# Altium schematic sheet Processor.SchDoc(Processor)
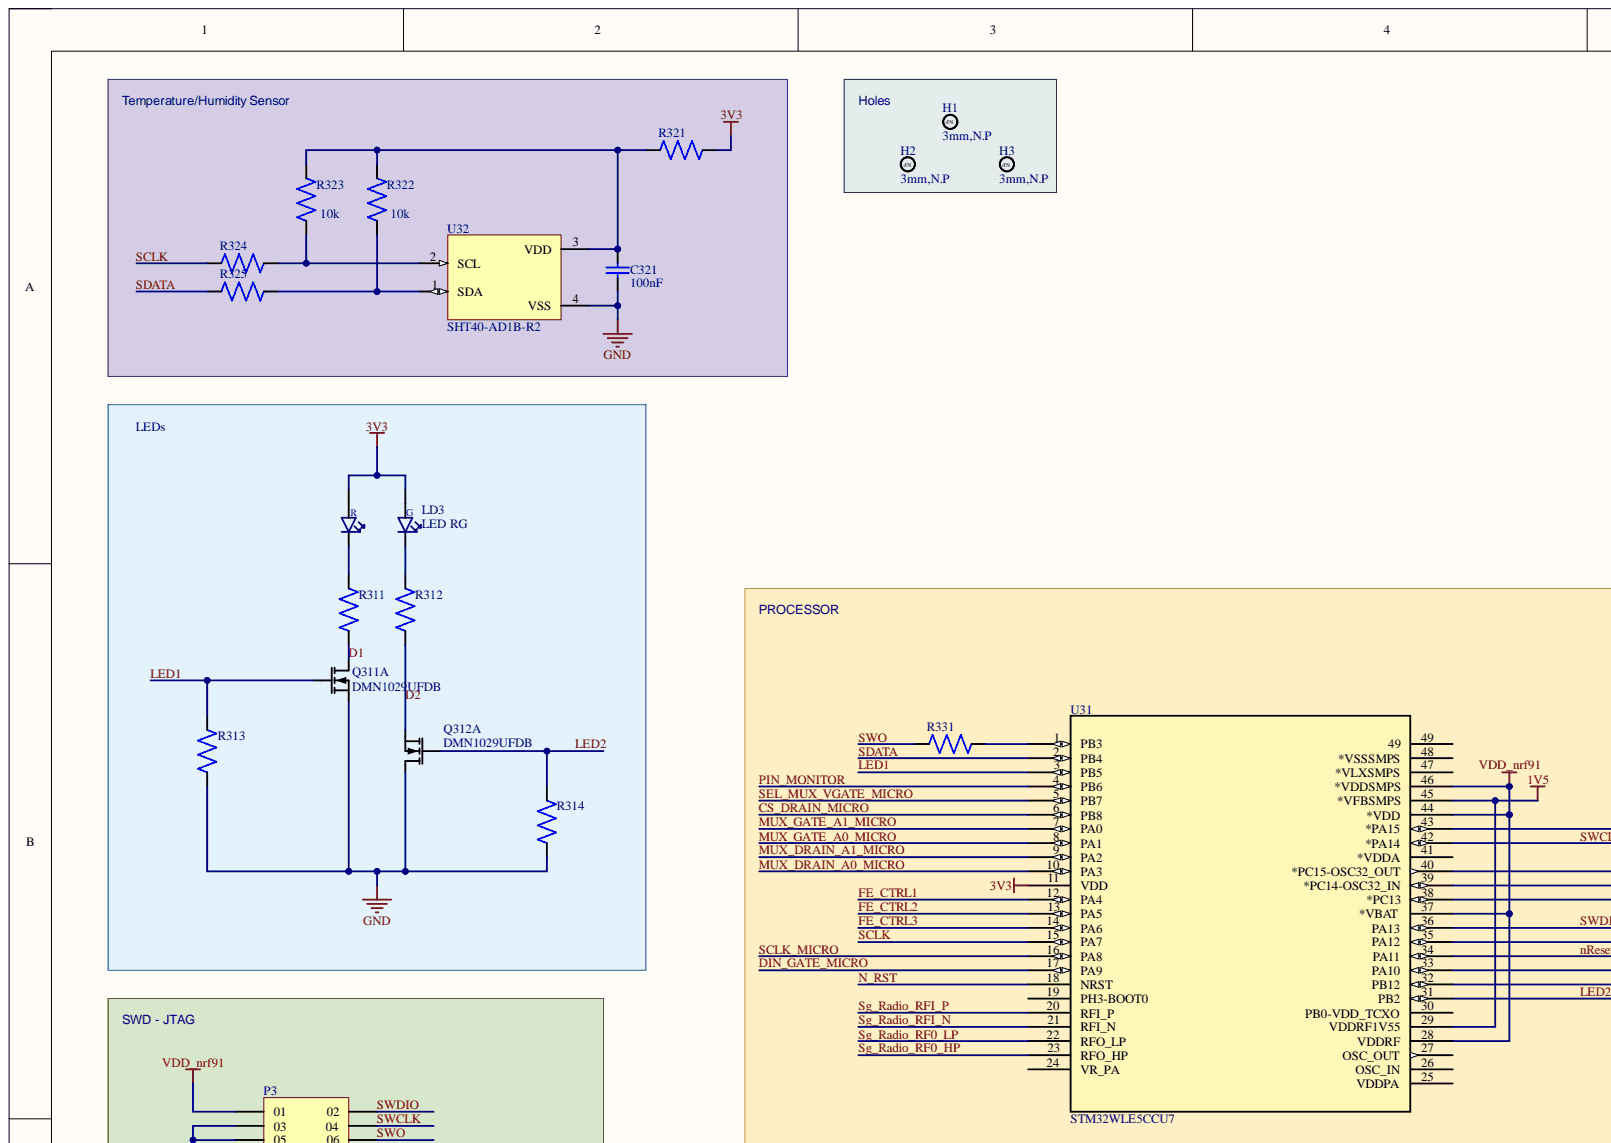
[diagram p0: Processor.SchDoc, top left]
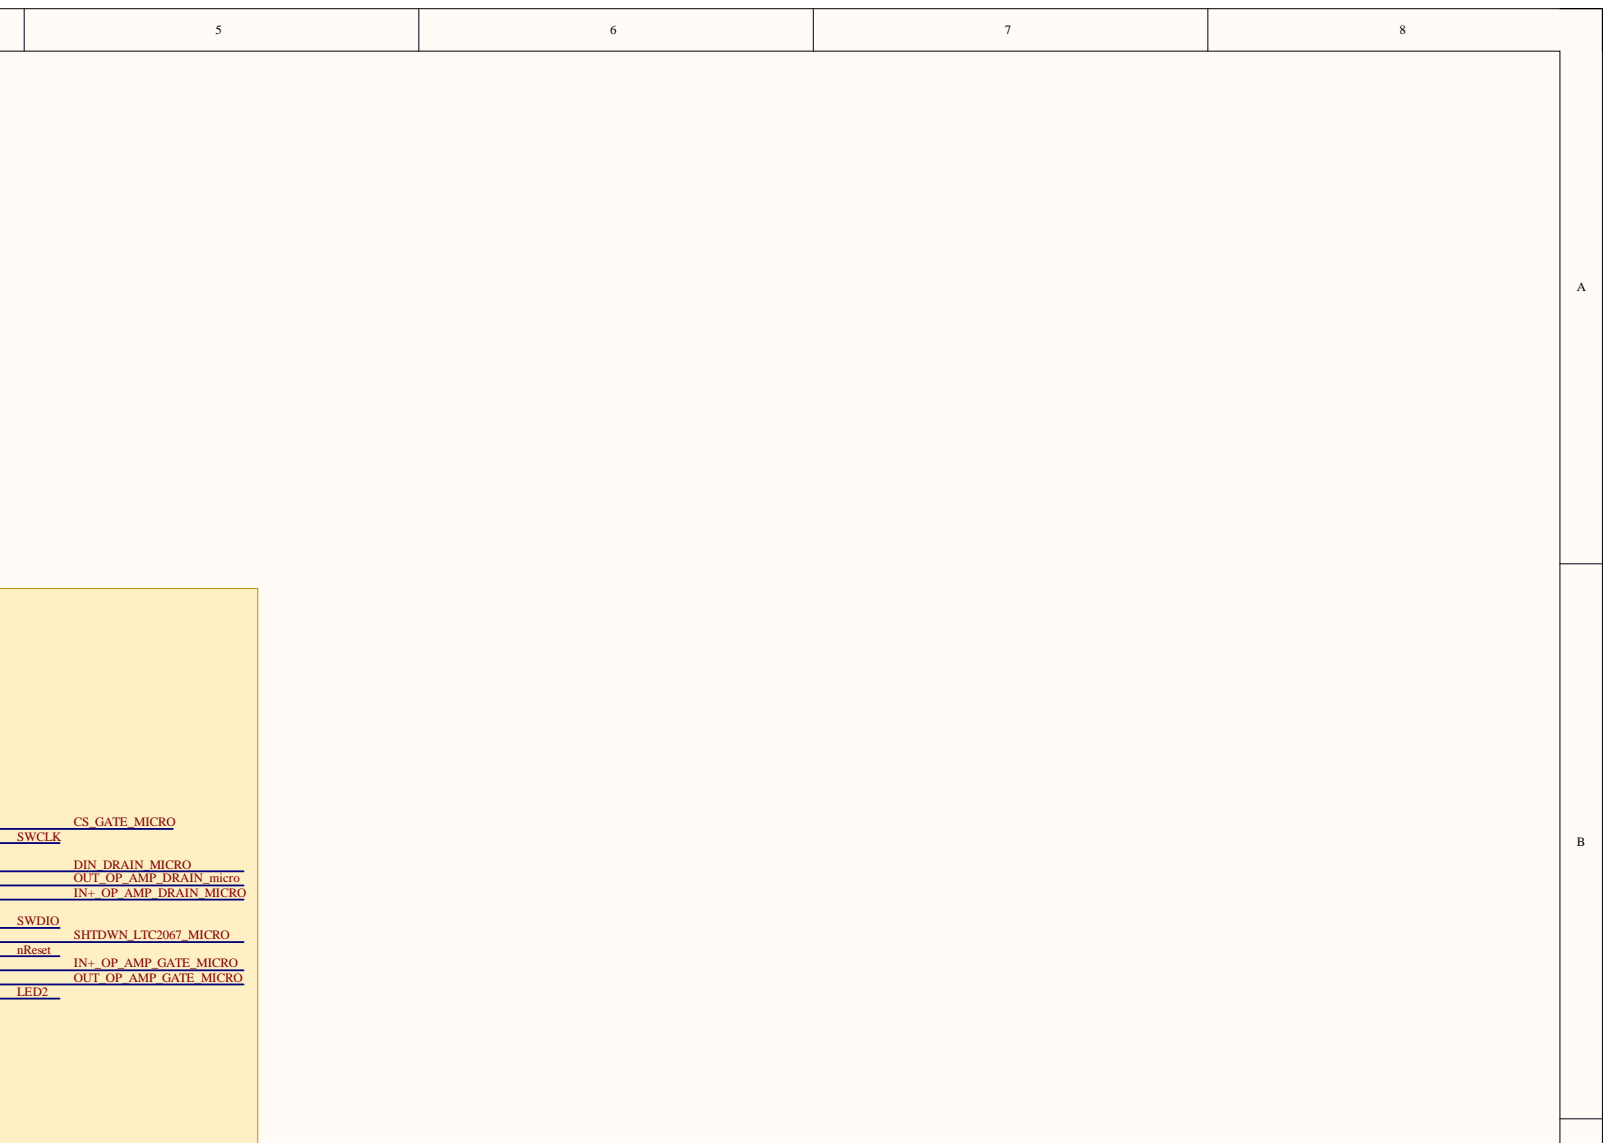
[diagram p1: Processor.SchDoc, top right]
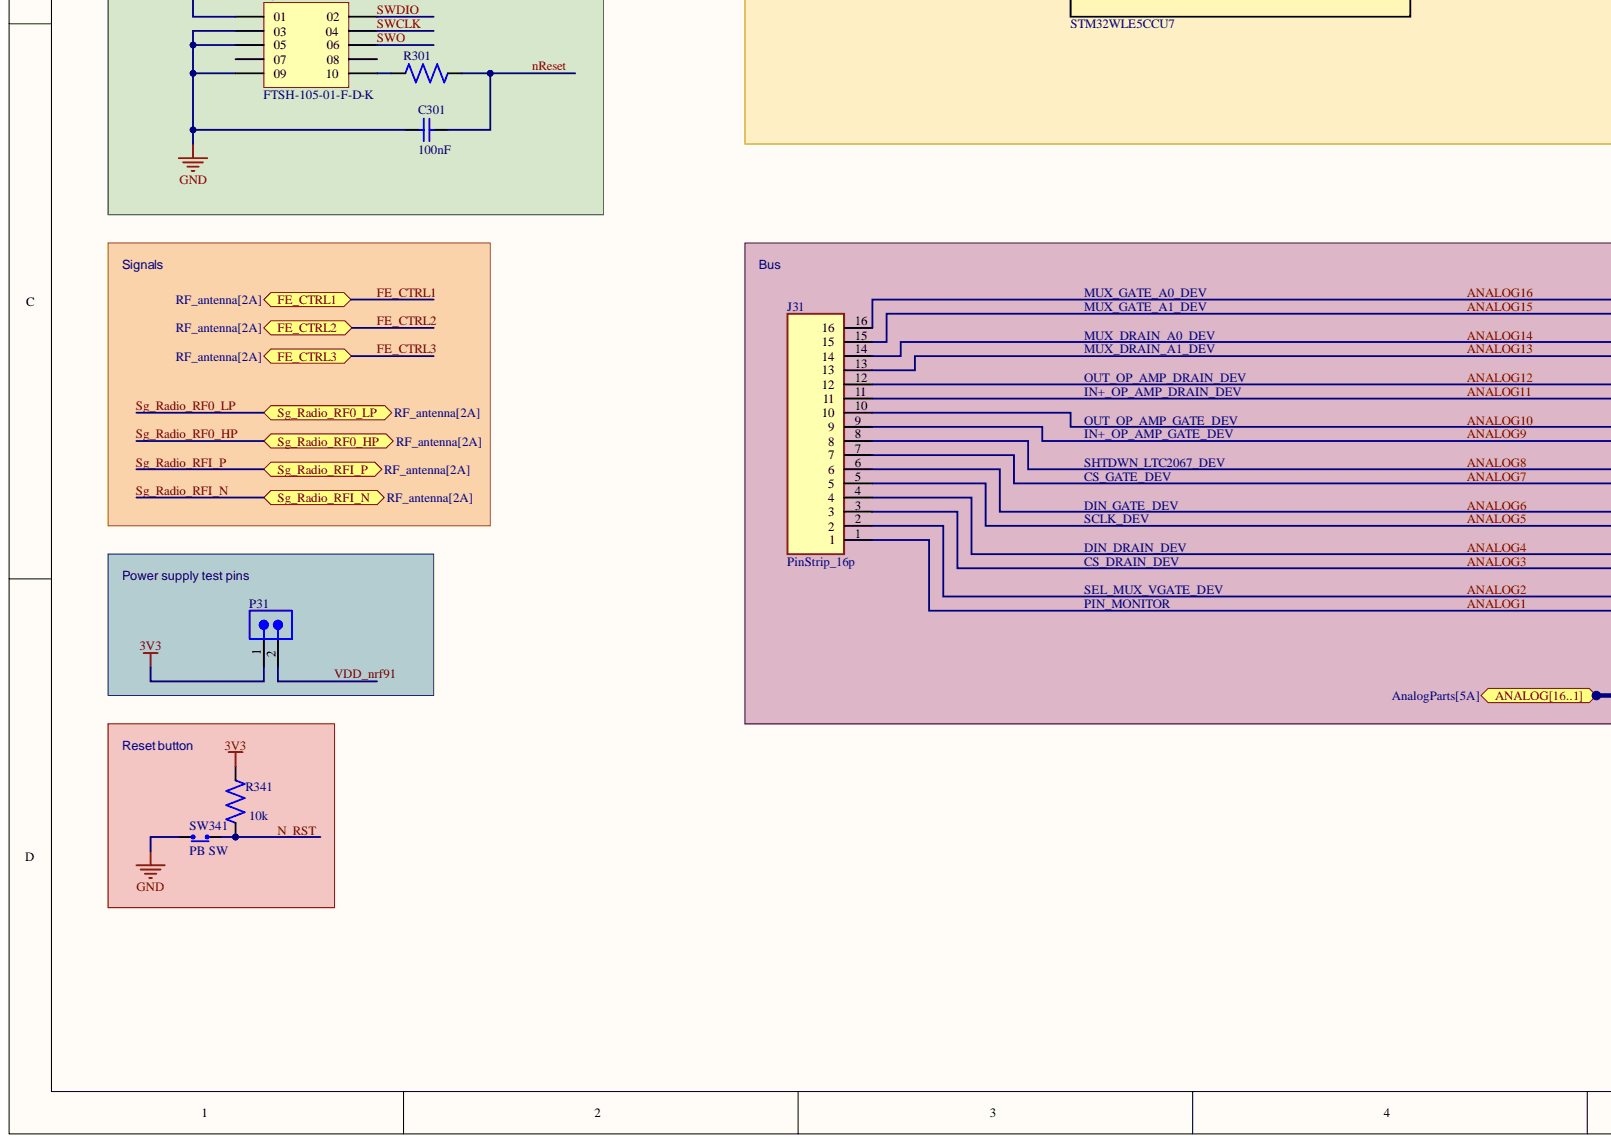
[diagram p2: Processor.SchDoc, bottom left]
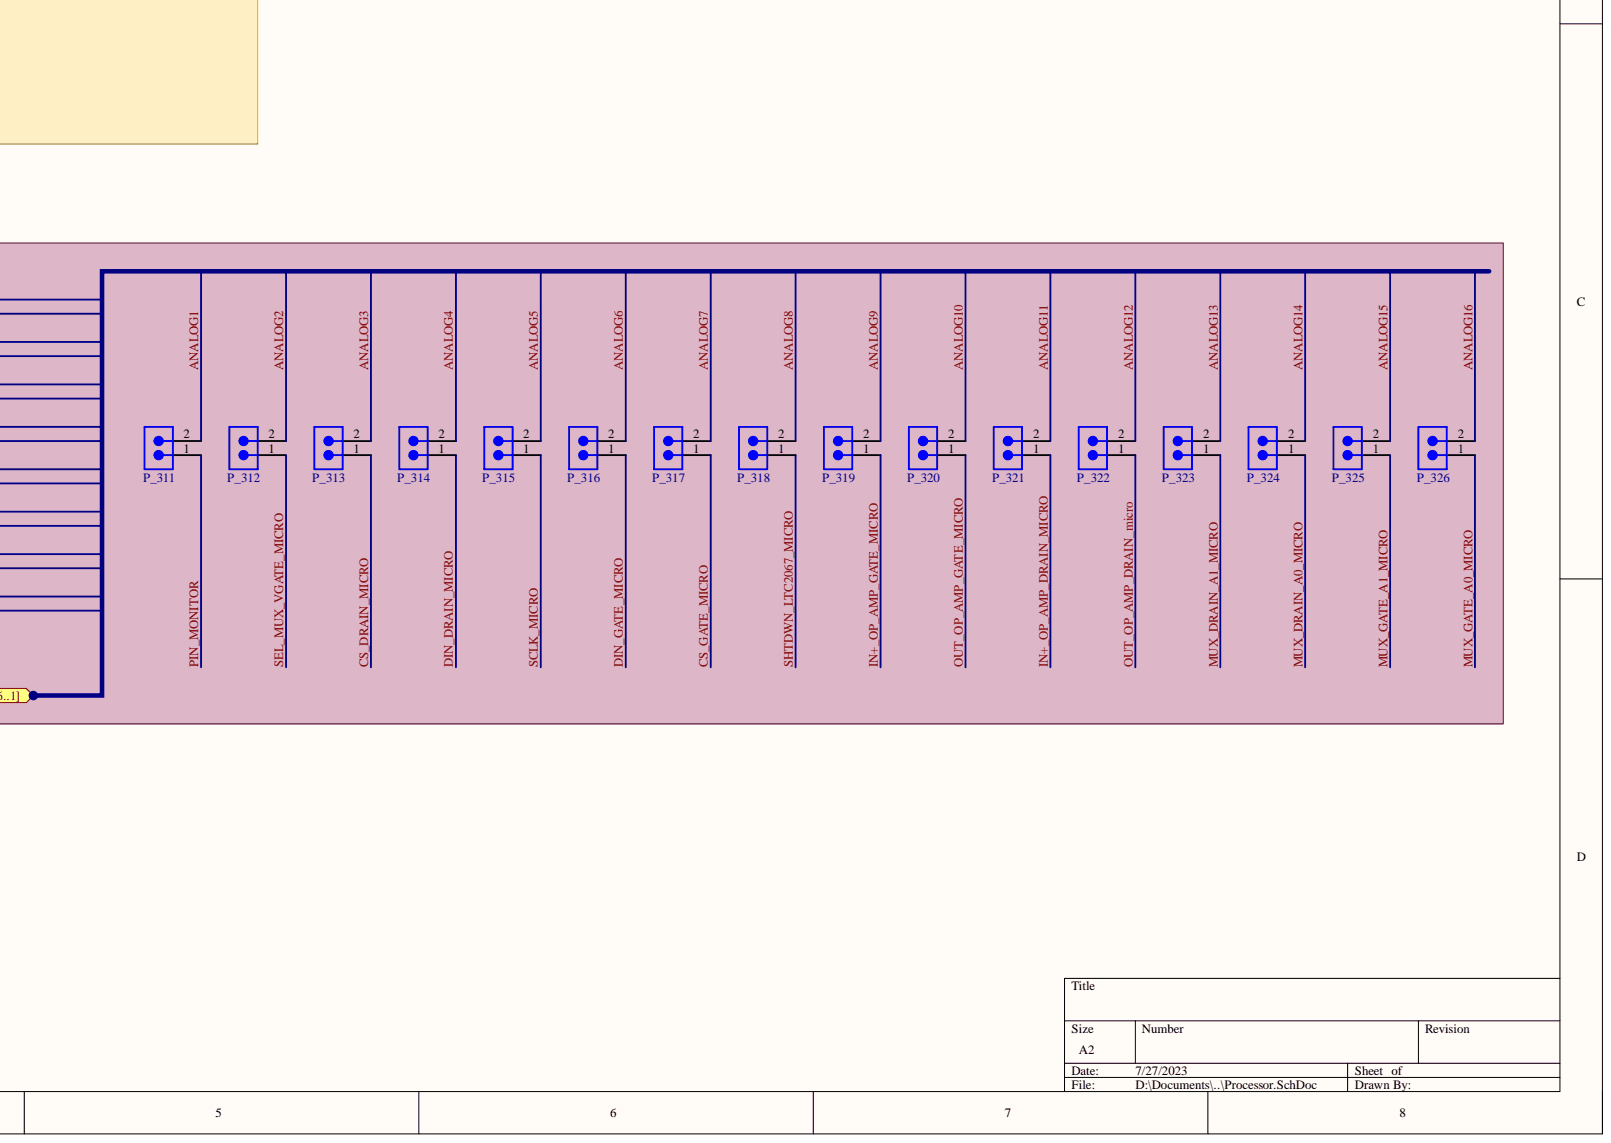
[diagram p3: Processor.SchDoc, bottom right]

## Parts
C301  100nF  [CAPC1608X06L]
C321  100nF  [CAPC1608X06L]
H1  3mm,N.P  [HOLE_NP_3MM]  (no BOM)
H2  3mm,N.P  [HOLE_NP_3MM]  (no BOM)
H3  3mm,N.P  [HOLE_NP_3MM]  (no BOM)
J31  PinStrip_16p  [PinStrip_16p]
LD3  LED RG  [LED_RGB_0805]
P3  FTSH-105-01-F-D-K  [HDR_2x5-THT-1.27mm-K]
P31  Pin header 2p  [Pin_header_1x2]  (value not drawn)
P_311  Pin header 2p  [Pin_header_1x2]  (value not drawn)
P_312  Pin header 2p  [Pin_header_1x2]  (value not drawn)
P_313  Pin header 2p  [Pin_header_1x2]  (value not drawn)
P_314  Pin header 2p  [Pin_header_1x2]  (value not drawn)
P_315  Pin header 2p  [Pin_header_1x2]  (value not drawn)
P_316  Pin header 2p  [Pin_header_1x2]  (value not drawn)
P_317  Pin header 2p  [Pin_header_1x2]  (value not drawn)
P_318  Pin header 2p  [Pin_header_1x2]  (value not drawn)
P_319  Pin header 2p  [Pin_header_1x2]  (value not drawn)
P_320  Pin header 2p  [Pin_header_1x2]  (value not drawn)
P_321  Pin header 2p  [Pin_header_1x2]  (value not drawn)
P_322  Pin header 2p  [Pin_header_1x2]  (value not drawn)
P_323  Pin header 2p  [Pin_header_1x2]  (value not drawn)
P_324  Pin header 2p  [Pin_header_1x2]  (value not drawn)
P_325  Pin header 2p  [Pin_header_1x2]  (value not drawn)
P_326  Pin header 2p  [Pin_header_1x2]  (value not drawn)
Q311  DMN1029UFDB  [MOSFET_DMP2160UFDB-7]  (part A)
Q312  DMN1029UFDB  [MOSFET_DMP2160UFDB-7]  (part A)
R301  (no value)  [RESC1608X04.5L]
R311  (no value)  [RESC1608X04.5L]
R312  (no value)  [RESC1608X04.5L]
R313  (no value)  [RESC1608X04.5L]
R314  (no value)  [RESC1608X04.5L]
R321  (no value)  [RESC1608X04.5L]
R322  10k  [RESC1608X04.5L]
R323  10k  [RESC1608X04.5L]
R324  (no value)  [RESC1608X04.5L]
R325  (no value)  [RESC1608X04.5L]
R331  (no value)  [RESC1608X04.5L]
R341  10k  [RESC1608X04.5L]
SW341  PB SW  [Switch-PB-6.2x6.3mm-SMD]
U31  STM32WLE5CCU7  [UFQFPN_5CCU7_STM]
U32  SHT40-AD1B-R2  [SHT4X]

## Ports
ANALOG1, ANALOG2, ANALOG3, ANALOG4, ANALOG5, ANALOG6, ANALOG7, ANALOG8, ANALOG9, ANALOG10, ANALOG11, ANALOG12, ANALOG13, ANALOG14, ANALOG15, ANALOG16, ANALOG[16..1], FE_CTRL1, FE_CTRL2, FE_CTRL3, Sg_Radio_RF0_HP, Sg_Radio_RF0_LP, Sg_Radio_RFI_N, Sg_Radio_RFI_P

## Nets
1V5: U31.29(VDDRF1V55) U31.45(*VFBSMPS)
3V3: LD3.3 LD3.4 P31.1 R321.2 R341.2 U31.11(VDD)
GND: C301.2 C321.1 P3.03 P3.05 P3.09 Q311.1 Q312.6 R313.1 R314.1 SW341.1 U32.4(VSS)
ANALOG1: J31.1 P_311.2 ; port ANALOG[16..1]  [also labelled ]
ANALOG2: J31.2 P_312.2 ; port ANALOG[16..1]  [also labelled ]
ANALOG3: J31.3 P_313.2 ; port ANALOG[16..1]  [also labelled ]
ANALOG4: J31.4 P_314.2 ; port ANALOG[16..1]  [also labelled ]
ANALOG5: J31.5 P_315.2 ; port ANALOG[16..1]  [also labelled ]
ANALOG6: J31.6 P_316.2 ; port ANALOG[16..1]  [also labelled ]
ANALOG7: J31.7 P_317.2 ; port ANALOG[16..1]  [also labelled ]
ANALOG8: J31.8 P_318.2 ; port ANALOG[16..1]  [also labelled ]
ANALOG9: J31.9 P_319.2 ; port ANALOG[16..1]  [also labelled ]
ANALOG10: J31.10 P_320.2 ; port ANALOG[16..1]  [also labelled ]
ANALOG11: J31.11 P_321.2 ; port ANALOG[16..1]  [also labelled ]
ANALOG12: J31.12 P_322.2 ; port ANALOG[16..1]  [also labelled ]
ANALOG13: J31.13 P_323.2 ; port ANALOG[16..1]  [also labelled ]
ANALOG14: J31.14 P_324.2 ; port ANALOG[16..1]  [also labelled ]
ANALOG15: J31.15 P_325.2 ; port ANALOG[16..1]  [also labelled ]
ANALOG16: J31.16 P_326.2 ; port ANALOG[16..1]  [also labelled ]
CS_DRAIN_MICRO: P_313.1 U31.6(PB8)
CS_GATE_MICRO: P_317.1 U31.43(*PA15)
D1: Q311.6 R311.1
D2: Q312.1 R312.1
DIN_DRAIN_MICRO: P_314.1 U31.40(*PC15-OSC32_OUT)
DIN_GATE_MICRO: P_316.1 U31.17(PA9)
FE_CTRL1: U31.12(PA4) ; port FE_CTRL1
FE_CTRL2: U31.13(PA5) ; port FE_CTRL2
FE_CTRL3: U31.14(PA6) ; port FE_CTRL3
IN+_OP_AMP_DRAIN_MICRO: P_321.1 U31.38(*PC13)
IN+_OP_AMP_GATE_MICRO: P_319.1 U31.33(PA10)
LED1: Q311.2 R313.2 U31.3(PB5)
LED2: Q312.2 R314.2 U31.31(PB2)
MUX_DRAIN_A0_MICRO: P_324.1 U31.10(PA3)
MUX_DRAIN_A1_MICRO: P_323.1 U31.9(PA2)
MUX_GATE_A0_MICRO: P_326.1 U31.8(PA1)
MUX_GATE_A1_MICRO: P_325.1 U31.7(PA0)
N_RST: R341.1 SW341.2 U31.18(NRST)
nReset: C301.1 R301.2 U31.34(PA11)
OUT_OP_AMP_DRAIN_micro: P_322.1 U31.39(*PC14-OSC32_IN)
OUT_OP_AMP_GATE_MICRO: P_320.1 U31.32(PB12)
PIN_MONITOR: P_311.1 U31.4(PB6)
SCLK: R324.1 U31.15(PA7)
SCLK_MICRO: P_315.1 U31.16(PA8)
SDATA: R325.1 U31.2(PB4)
SEL_MUX_VGATE_MICRO: P_312.1 U31.5(PB7)
Sg_Radio_RF0_HP: U31.23(RFO_HP) ; port Sg_Radio_RF0_HP
Sg_Radio_RF0_LP: U31.22(RFO_LP) ; port Sg_Radio_RF0_LP
Sg_Radio_RFI_N: U31.21(RFI_N) ; port Sg_Radio_RFI_N
Sg_Radio_RFI_P: U31.20(RFI_P) ; port Sg_Radio_RFI_P
SHTDWN_LTC2067_MICRO: P_318.1 U31.35(PA12)
SWCLK: P3.04 U31.42(*PA14)
SWDIO: P3.02 U31.36(PA13)
SWO: P3.06 R331.1
VDD_nrf91: P3.01 P31.2 U31.28(VDDRF) U31.37(*VBAT) U31.44(*VDD) U31.46(*VDDSMPS)
NetC321_2: C321.2 R321.1 R322.2 R323.2 U32.3(VDD)
NetLD3_1: LD3.1 R312.2
NetLD3_2: LD3.2 R311.2
NetP3_10: P3.10 R301.1
NetR322_1: R322.1 R325.2 U32.1(SDA)
NetR323_1: R323.1 R324.2 U32.2(SCL)
NetR331_2: R331.2 U31.1(PB3)

Not connected: P3 07 08; U31 19(PH3-BOOT0) 24(VR_PA) 25(VDDPA) 26(OSC_IN) 27(OSC_OUT) 30(PB0-VDD_TCXO) 41(*VDDA) 47(*VLXSMPS) 48(*VSSSMPS) 49

## Library data of the parts
C301 C321 = C0603-4µ7: Capacitor, X5R, ±10%; Component Kind: Standard; Value: 100nF; Library Name: ProgettoAgrifoodLibreriaSCH.SchLib
H1-H3 = Hole_3MM_NP: Regular Non-Plated, 3mm hole; Value: 3mm,N.P; Library Name: ProgettoAgrifoodLibreriaSCH.SchLib
J31 = PinStrip_16p: Connector; Manufacturer_Name: Adam Tech; Manufacturer_Part_Number: PH1-16-UA; Arrow Part Number: PH1-16-UA; Arrow Price/Stock: https://www.arrow.com/en/products/ph1-16-ua/adam-tech?region=nac; Component Kind: Standard; Datasheet Link: https://www.arrow.com/en/products/ph1-16-ua/adam-tech; Height: 8.469mm; Library Name: add2.SchLib
LD3 = APHBM2012LSURKZGKC: Red green LED 2 mm x 1.25 mm SMD; Component Kind: Standard; Value: LED RG; Library Name: ProgettoAgrifoodLibreriaSCH.SchLib
P3 = Pin Header 2x5 THT, 1.27mm KS: Connector Header Through Hole 10 position 0.050 (1.27mm); MF: Samtec; Availability: Bad; Component Kind: Standard; MP: FTSH-105-01-F-D-K; Package: None; Price: None; SnapEDA_Link: https://www.snapeda.com/parts/FTSH-105-01-F-D-K/Samtec%20Inc./view-part/229423/?ref=snap; Toby_UK-Purchase-URL: https://snapeda.com/shop?store=Toby+UK&id=229423; Value: FTSH-105-01-F-D-K; Library Name: ProgettoAgrifoodLibreriaSCH.SchLib
P31 P_311-P_326 = Pin header 2p: Pin Header, THT, Vertical, pitch 2.54mm, 1 Row, 2P; Component Kind: Standard; Value: Pin header 2p; Library Name: ProgettoAgrifoodLibreriaSCH.SchLib
Q311 Q312 = DMN1029UFDB: Dual N Ch MOFET, 5.6A, 29mOhm max, 1.4W; Component Kind: Standard; Value: DMN1029UFDB; Library Name: ProgettoAgrifoodLibreriaSCH.SchLib
R301 R311-R314 R321 R324 R325 R331 = R0603: Resistor, ±1%, 0.063W; Component Kind: Standard; Library Name: ProgettoAgrifoodLibreriaSCH.SchLib
R322 R341 = R0603: Resistor, ±1%, 0.063W; Value: 10K ohm; Component Kind: Standard; Library Name: ProgettoAgrifoodLibreriaSCH.SchLib
R323 = R0603: Resistor, ±1%, 0.063W; Value: 10k ohm; Component Kind: Standard; Library Name: ProgettoAgrifoodLibreriaSCH.SchLib
SW341 = Switch-PB-6.2x6.3mm-SMD: Tactile Switch, SPNO, SMD, 160gf, 6.2x6.3x2.5mm; Component Kind: Standard; Value: PB SW; Library Name: ProgettoAgrifoodLibreriaSCH.SchLib
U31 = STM32WLE5CCU7: No Description Available; Mfr_Name: STMicroelectronics; Component Kind: Standard; Library Name: STM32WLE5CCU7.SchLib; RefDes: RefDes; Type: Type
U32 = SHT40-AD1B-R2: Humidity/Temperature Sensor Digital Serial (I2C) 4-Pin DFN EP T/R; MF: Sensirion AG; Availability: In Stock; Check_prices: https://www.snapeda.com/parts/SHT40-AD1B-R2/NSI/view-part/?ref=eda; Component Kind: Standard; MP: SHT40-AD1B-R2; Package: None; Price: None; Purchase-URL: https://pricing.snapeda.com/search/part/SHT40-AD1B-R2/?ref=eda; SnapEDA_Link: https://www.snapeda.com/parts/SHT40-AD1B-R2/NSI/view-part/?ref=snap; Value: SHT40-AD1B-R2; Library Name: ProgettoAgrifoodLibreriaSCH.SchLib
